# Altium schematic sheet PDU.SchDoc
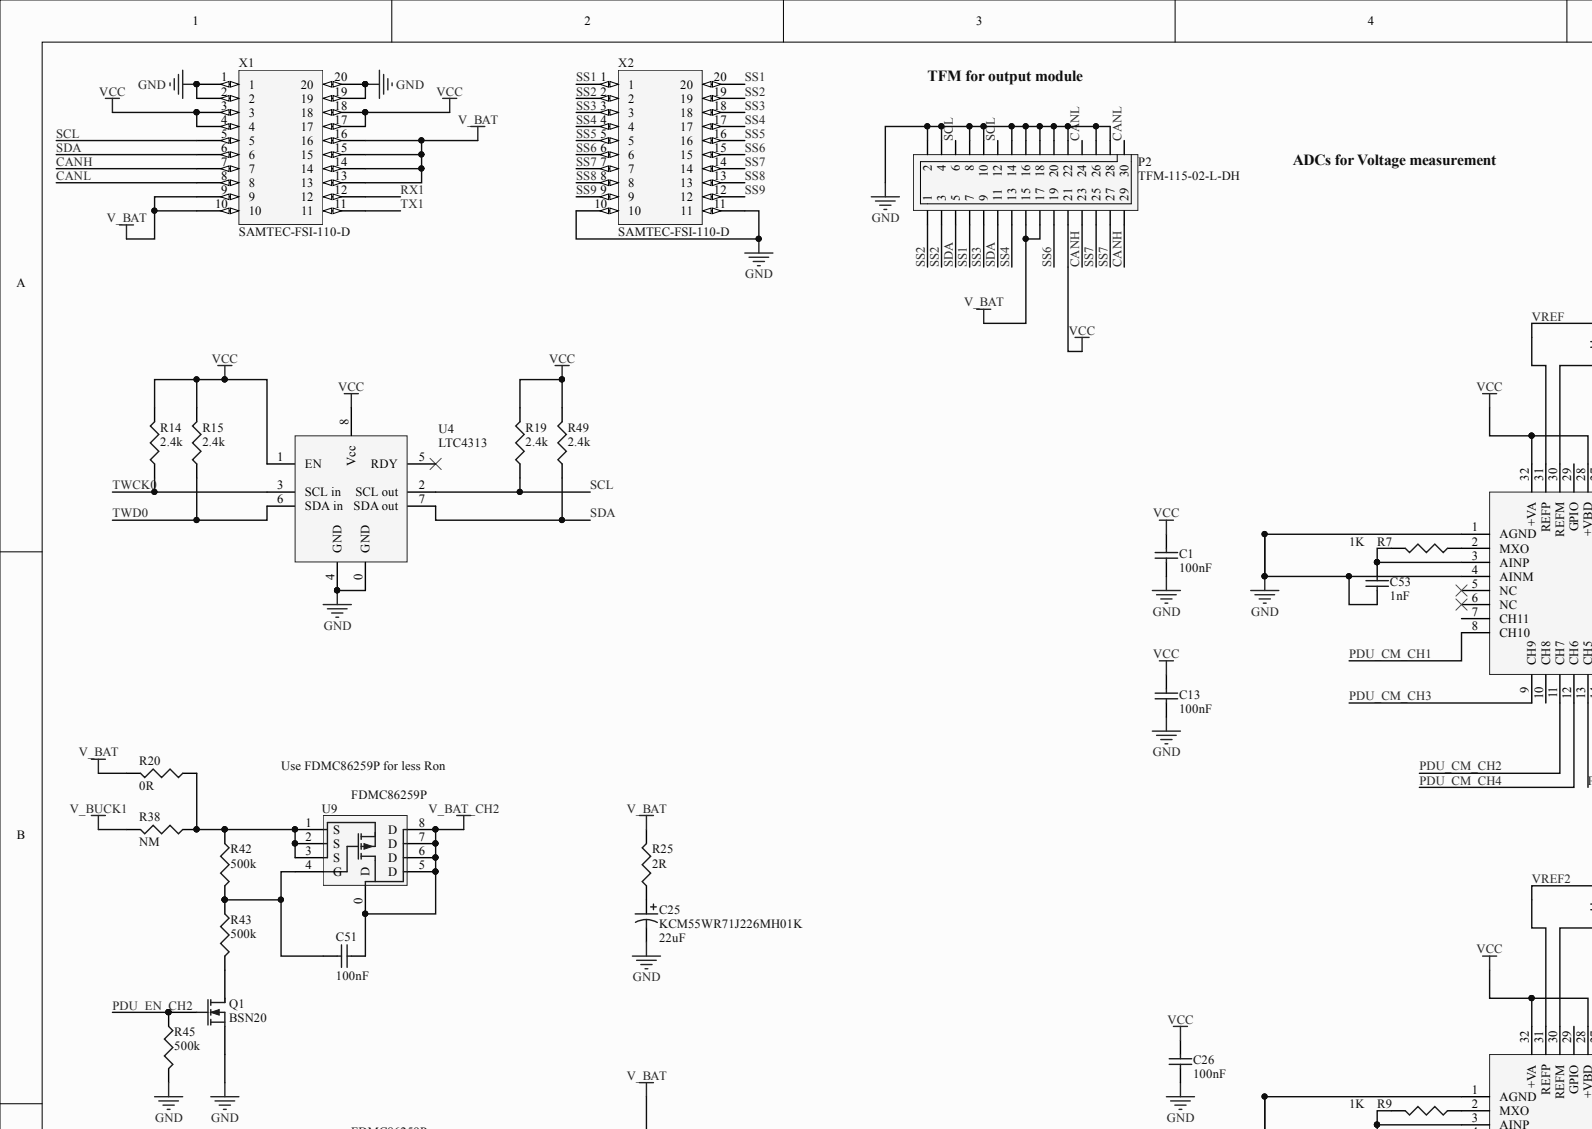
[diagram p0: PDU.SchDoc, top left]
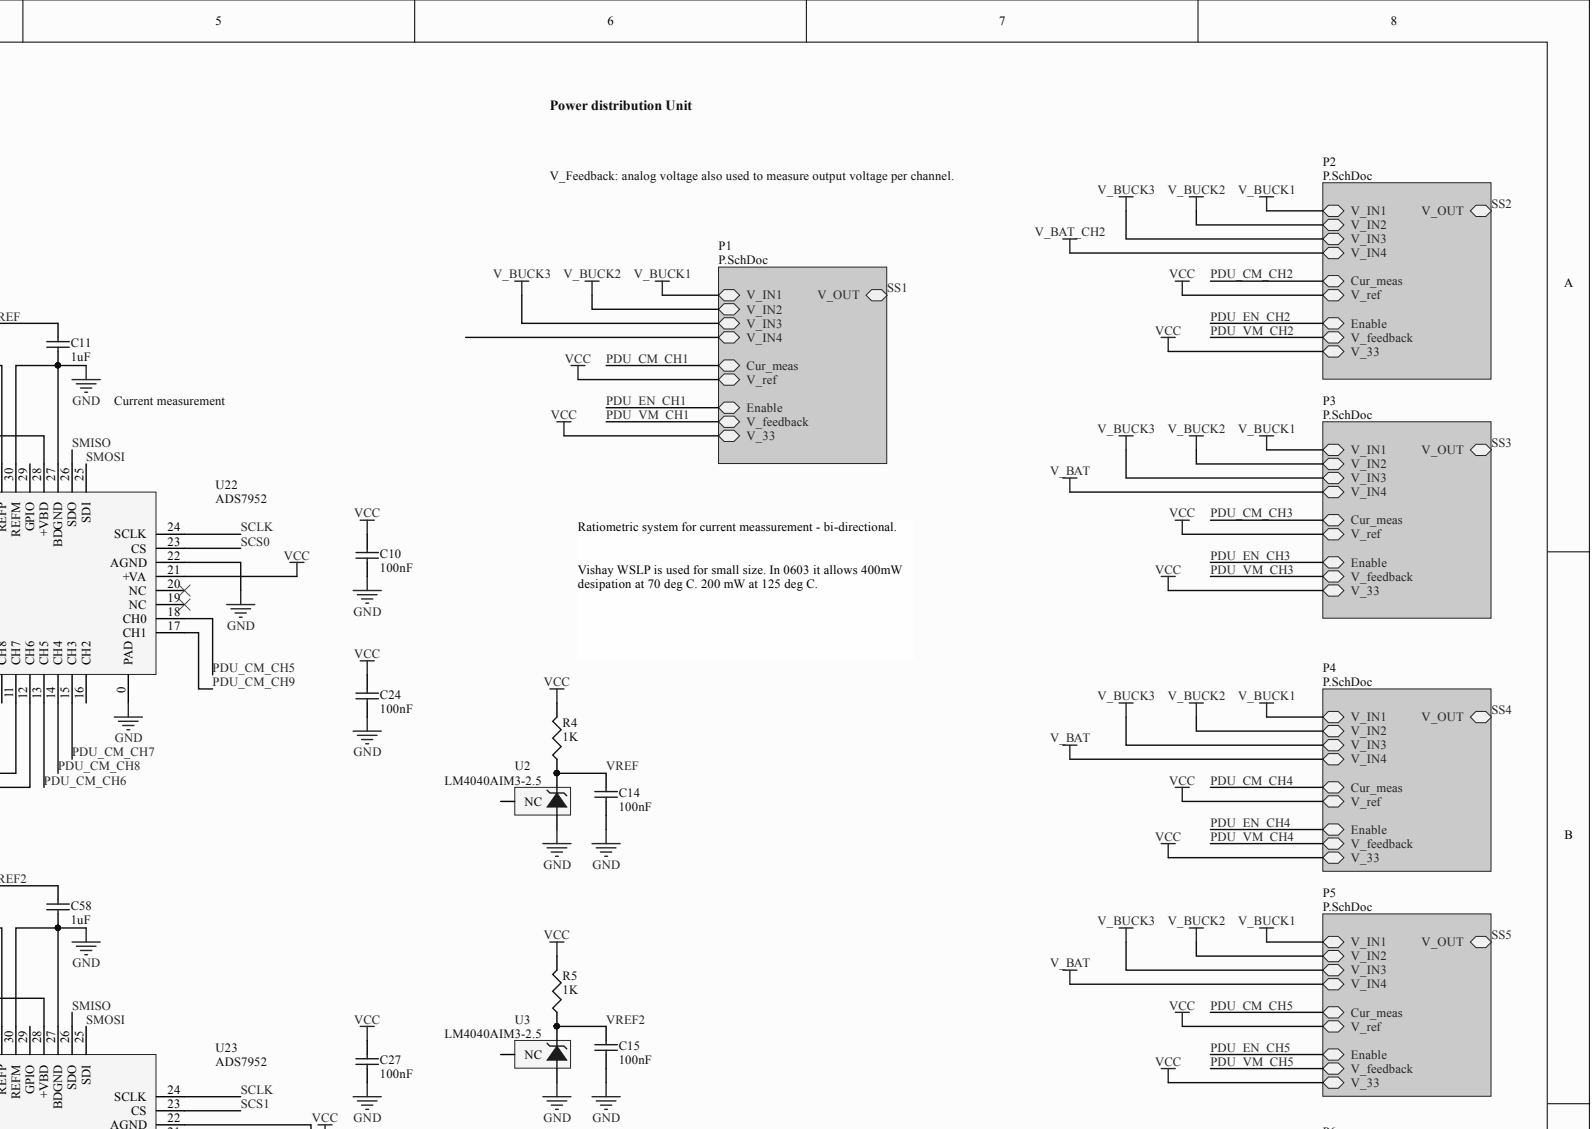
[diagram p1: PDU.SchDoc, top right]
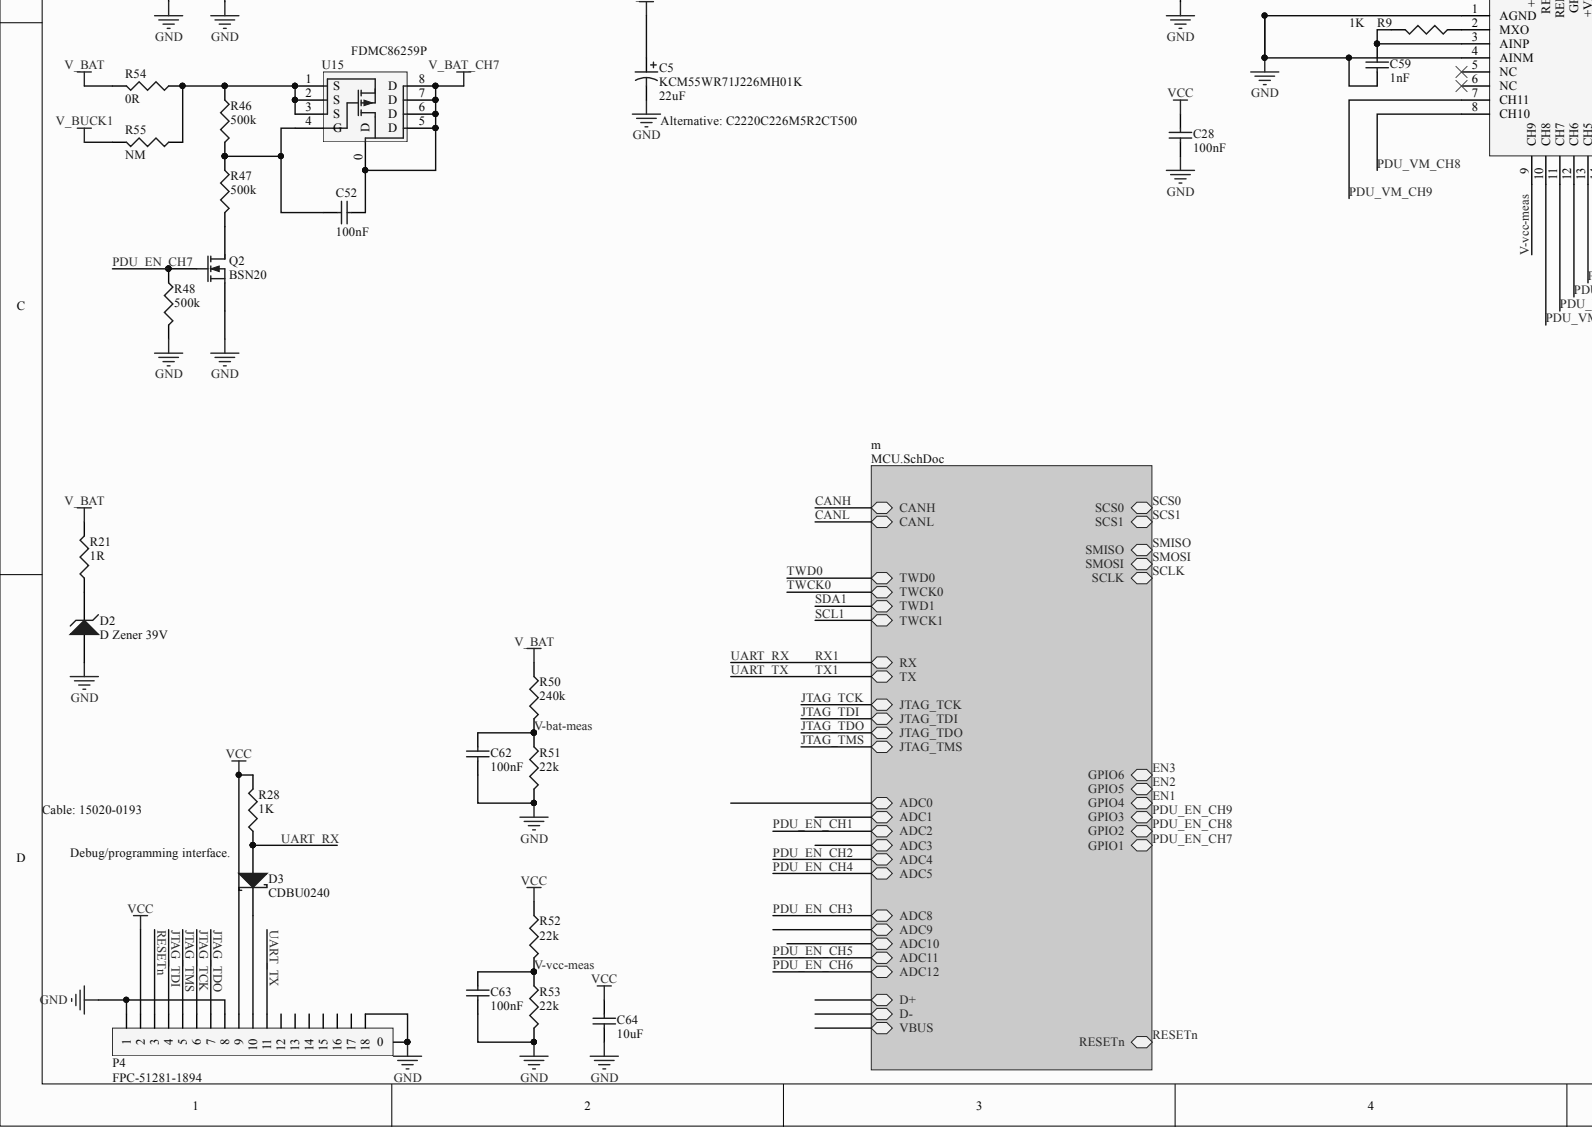
[diagram p2: PDU.SchDoc, bottom left]
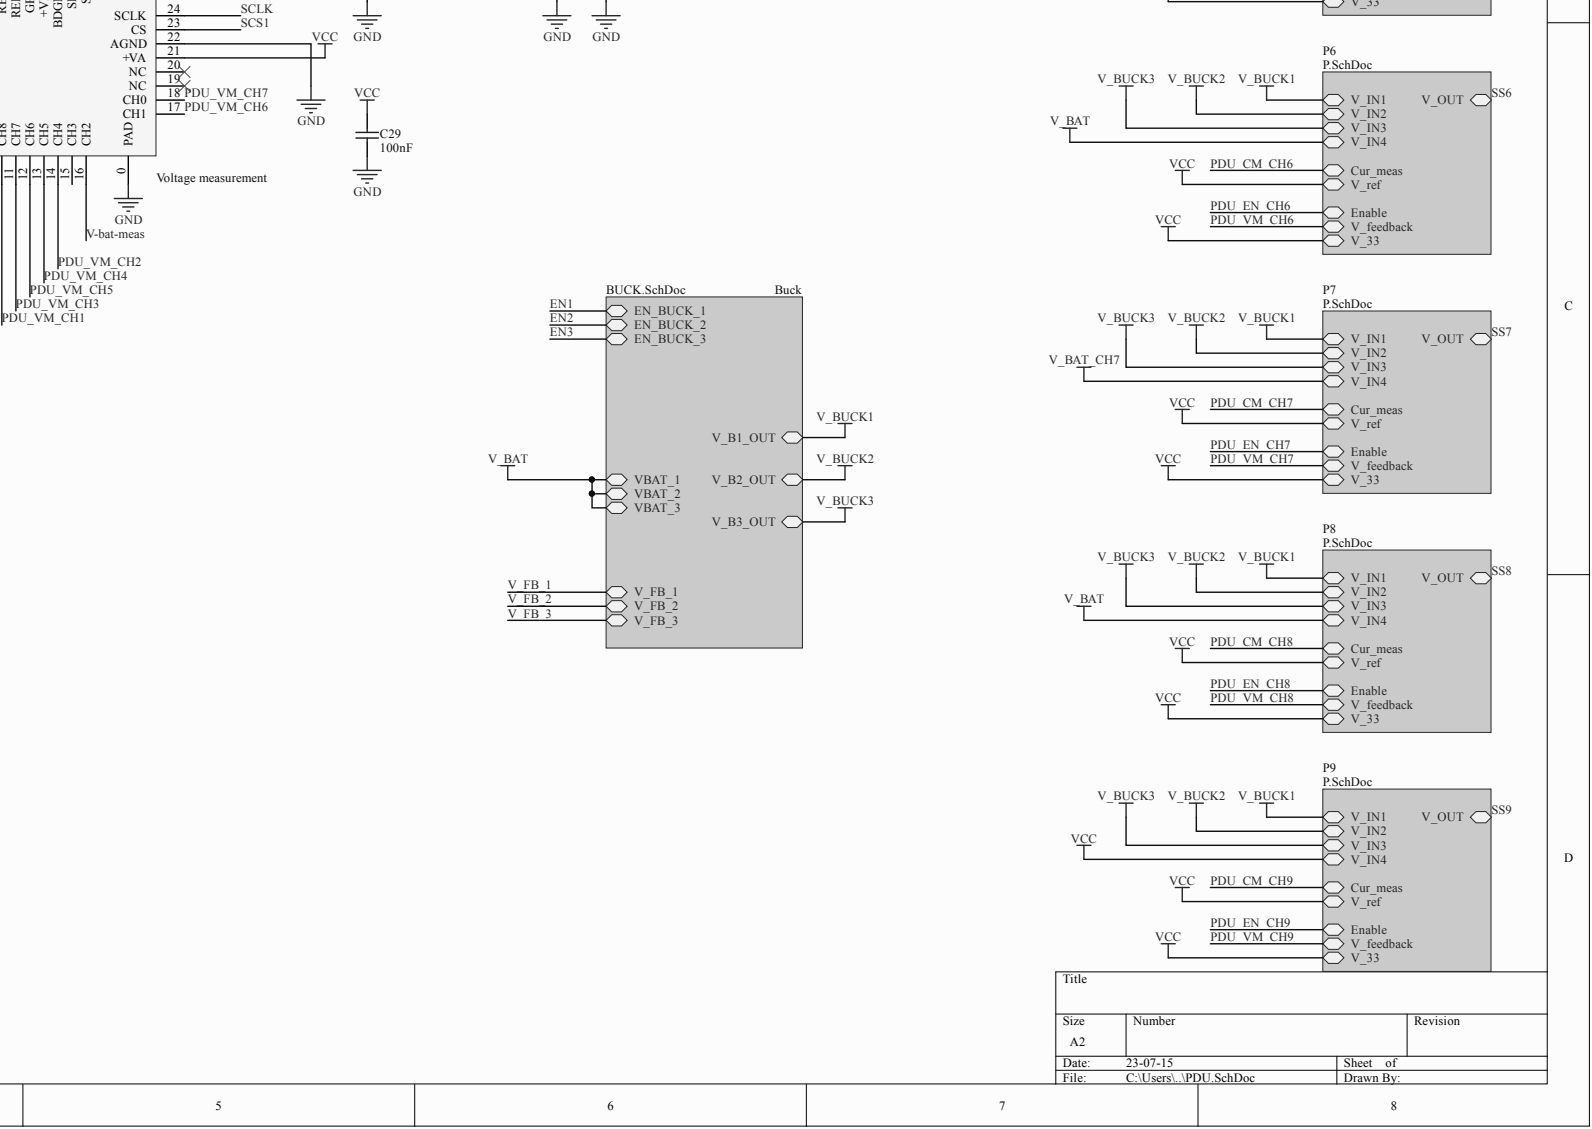
[diagram p3: PDU.SchDoc, bottom right]

## Parts
C1  value 100nF  [0402]  (hidden comment: Cap Semi)
C5  KCM55WR71J226MH01K  value 22uF  [2220-stacked-6.7]
C10  value 100nF  [0402]  (hidden comment: Cap Semi)
C11  value 1uF  [0402]  (hidden comment: Cap Semi)
C13  value 100nF  [0402]  (hidden comment: Cap Semi)
C14  value 100nF  [0402]  (hidden comment: Cap Semi)
C15  value 100nF  [0402]  (hidden comment: Cap Semi)
C24  value 100nF  [0402]  (hidden comment: Cap Semi)
C25  KCM55WR71J226MH01K  value 22uF  [2220-stacked-6.7]
C26  value 100nF  [0402]  (hidden comment: Cap Semi)
C27  value 100nF  [0402]  (hidden comment: Cap Semi)
C28  value 100nF  [0402]  (hidden comment: Cap Semi)
C29  value 100nF  [0402]  (hidden comment: Cap Semi)
C51  value 100nF  [0402]  (hidden comment: Cap Semi)
C52  value 100nF  [0402]  (hidden comment: Cap Semi)
C53  value 1nF  [0402]  (hidden comment: Cap Semi)
C58  value 1uF  [0402]  (hidden comment: Cap Semi)
C59  value 1nF  [0402]  (hidden comment: Cap Semi)
C62  value 100nF  [0402]  (hidden comment: Cap Semi)
C63  value 100nF  [0402]  (hidden comment: Cap Semi)
C64  value 10uF  [1608[0603]]  (hidden comment: Cap Semi)
D2  D Zener 39V  [0603]
D3  CDBU0240  [CD1608-0603]
P2  TFM-115-02-L-DH  [TFM-115-02-X-DH]
P4  FPC-51281-1894  [51281-1894]
Q1  BSN20  [SOT23_n]
Q2  BSN20  [SOT23_n]
R4  value 1K  [0402]  (hidden comment: Res3)
R5  value 1K  [0402]  (hidden comment: Res3)
R7  value 1K  [0402]  (hidden comment: Res3)
R9  value 1K  [0402]  (hidden comment: Res3)
R14  value 2.4k  [0402]  (hidden comment: Res3)
R15  value 2.4k  [0402]  (hidden comment: Res3)
R19  value 2.4k  [0402]  (hidden comment: Res3)
R20  value 0R  [0603R]  (hidden comment: Res3)
R21  value 1R  [J1-0603]  (hidden comment: Res3)
R25  value 2R  [14-1210]  (hidden comment: Res3)
R28  value 1K  [0402]  (hidden comment: Res3)
R38  value NM  [0603R]  (hidden comment: Res3)
R42  value 500k  [0402]  (hidden comment: Res3)
R43  value 500k  [0402]  (hidden comment: Res3)
R45  value 500k  [0402]  (hidden comment: Res3)
R46  value 500k  [0402]  (hidden comment: Res3)
R47  value 500k  [0402]  (hidden comment: Res3)
R48  value 500k  [0402]  (hidden comment: Res3)
R49  value 2.4k  [0402]  (hidden comment: Res3)
R50  value 240k  [0402]  (hidden comment: Res3)
R51  value 22k  [0402]  (hidden comment: Res3)
R52  value 22k  [0402]  (hidden comment: Res3)
R53  value 22k  [0402]  (hidden comment: Res3)
R54  value 0R  [0603R]  (hidden comment: Res3)
R55  value NM  [0603R]  (hidden comment: Res3)
U2  LM4040AIM3-2.5  [SOT23_N]
U3  LM4040AIM3-2.5  [SOT23_N]
U4  LTC4313  [DFN8-3x3-0.5p]
U9  FDMC86259P  [power33]
U15  FDMC86259P  [power33]
U22  ADS7952  [QFN32-5x5mm]
U23  ADS7952  [QFN32-5x5mm]
X1  SAMTEC-FSI-110-D  [SAMTEC-FSI-110-D]
X2  SAMTEC-FSI-110-D  [SAMTEC-FSI-110-D]

## Nets
GND: C1.1 C5.2 C10.1 C11.2 C13.1 C14.1 C15.1 C24.1 C25.2 C26.1 C27.1 C28.1 C29.1 C53.1 C58.2 C59.1 C62.1 C63.1 C64.1 D2.1 P2.2 P2.4 P2.8 P2.10 P2.14 P2.16 P2.18 P2.20 P2.22 P2.26 P2.28 P4.0 P4.1 P4.8 P4.18 Q1.3 Q2.3 R45.2 R48.2 R51.2 R53.2 U2.2 U3.2 U4.0(GND) U4.4(GND) U22.0(PAD) U22.1(AGND) U22.4(AINM) U22.22(AGND) U22.27(BDGND) U22.30(REFM) U23.0(PAD) U23.1(AGND) U23.4(AINM) U23.22(AGND) U23.27(BDGND) U23.30(REFM) X1.1 X1.2 X1.19 X1.20 X2.10 X2.11
V_BAT: C5.1 P2.15 P2.17 R20.2 R21.2 R25.2 R50.1 R54.2 X1.9 X1.10 X1.13 X1.14 X1.15 X1.16
V_BAT_CH2: C51.1 U9.0(D) U9.5(D) U9.6(D) U9.7(D) U9.8(D)
V_BAT_CH7: C52.1 U15.0(D) U15.5(D) U15.6(D) U15.7(D) U15.8(D)
V_BUCK1: R38.2 R55.2
VCC: C1.2 C10.2 C13.2 C24.2 C26.2 C27.2 C28.2 C29.2 C64.2 P2.21 P4.2 P4.9 R4.2 R5.2 R14.2 R15.2 R19.2 R28.2 R49.2 R52.1 U4.1(EN) U4.8(Vcc) U22.21(+VA) U22.28(+VBD) U22.32(+VA) U23.21(+VA) U23.28(+VBD) U23.32(+VA) X1.3 X1.4 X1.17 X1.18
CANH: P2.23 P2.29 X1.7
CANL: P2.24 P2.30 X1.8
JTAG_TCK: P4.6
JTAG_TDI: P4.4
JTAG_TDO: P4.7
JTAG_TMS: P4.5
PDU_CM_CH1: U22.8(CH10)
PDU_CM_CH2: U22.11(CH7)
PDU_CM_CH3: U22.9(CH9)
PDU_CM_CH4: U22.12(CH6)
PDU_CM_CH5: U22.18(CH0)
PDU_CM_CH6: U22.13(CH5)
PDU_CM_CH7: U22.15(CH3)
PDU_CM_CH8: U22.14(CH4)
PDU_CM_CH9: U22.17(CH1)
PDU_EN_CH2: Q1.2 R45.1
PDU_EN_CH7: Q2.2 R48.1
PDU_VM_CH1: U23.10(CH8)
PDU_VM_CH2: U23.14(CH4)
PDU_VM_CH3: U23.11(CH7)
PDU_VM_CH4: U23.13(CH5)
PDU_VM_CH5: U23.12(CH6)
PDU_VM_CH6: U23.17(CH1)
PDU_VM_CH7: U23.18(CH0)
PDU_VM_CH8: U23.8(CH10)
PDU_VM_CH9: U23.7(CH11)
RESETN: P4.3
RX1: D3.1 R28.1 X1.12
SCL: P2.6 P2.12 R19.1 U4.2(SCL_out) X1.5
SCLK: U22.24(SCLK) U23.24(SCLK)
SCS0: U22.23(CS)
SCS1: U23.23(CS)
SDA: P2.5 P2.11 R49.1 U4.7(SDA_out) X1.6
SMISO: U22.26(SDO) U23.26(SDO)
SMOSI: U22.25(SDI) U23.25(SDI)
SS1: P2.7 X2.1 X2.20
SS2: P2.1 P2.3 X2.2 X2.19
SS3: P2.9 X2.3 X2.18
SS4: P2.13 X2.4 X2.17
SS5: X2.5 X2.16
SS6: P2.19 X2.6 X2.15
SS7: P2.25 P2.27 X2.7 X2.14
SS8: X2.8 X2.13
SS9: X2.9 X2.12
TWCK0: R14.1 U4.3(SCL_in)
TWD0: R15.1 U4.6(SDA_in)
TX1: P4.11 X1.11
V-BAT-MEAS: C62.2 R50.2 R51.1 U23.16(CH2)
V-VCC-MEAS: C63.2 R52.2 R53.1 U23.9(CH9)
VREF: C11.1 C14.2 R4.1 U2.1 U22.31(REFP)
VREF2: C15.2 C58.1 R5.1 U3.1 U23.31(REFP)
NetC25_1: C25.1 R25.1
NetC51_2: C51.2 R42.2 R43.1 U9.4(G)
NetC52_2: C52.2 R46.2 R47.1 U15.4(G)
NetC53_2: C53.2 R7.2 U22.3(AINP)
NetC59_2: C59.2 R9.2 U23.3(AINP)
NetD2_2: D2.2 R21.1
NetD3_2: D3.2 P4.10
NetP4_12: P4.12
NetP4_13: P4.13
NetP4_14: P4.14
NetP4_15: P4.15
NetP4_16: P4.16
NetP4_17: P4.17
NetQ1_1: Q1.1 R43.2
NetQ2_1: Q2.1 R47.2
NetR7_1: R7.1 U22.2(MXO)
NetR9_1: R9.1 U23.2(MXO)
NetR20_1: R20.1 R38.1 R42.1 U9.1(S) U9.2(S) U9.3(S)
NetR46_1: R46.1 R54.1 R55.1 U15.1(S) U15.2(S) U15.3(S)
NetU2_3: U2.3
NetU3_3: U3.3
NetU4_5: U4.5(RDY)
NetU22_5: U22.5(NC)
NetU22_6: U22.6(NC)
NetU22_7: U22.7(CH11)
NetU22_10: U22.10(CH8)
NetU22_16: U22.16(CH2)
NetU22_19: U22.19(NC)
NetU22_20: U22.20(NC)
NetU22_29: U22.29(GPIO)
NetU23_5: U23.5(NC)
NetU23_6: U23.6(NC)
NetU23_15: U23.15(CH3)
NetU23_19: U23.19(NC)
NetU23_20: U23.20(NC)
NetU23_29: U23.29(GPIO)
ADC0:
ADC1:
ADC3:
ADC9:
ADC10:
D+:
D-:
EN1:
EN2:
EN3:
PDU_EN_CH1:
PDU_EN_CH3:
PDU_EN_CH4:
PDU_EN_CH5:
PDU_EN_CH6:
PDU_EN_CH8:
PDU_EN_CH9:
SCL1:
SDA1:
V_BUCK2:
V_BUCK3:
V_FB_1:
V_FB_2:
V_FB_3:
V_IN4:
VBUS:

## Library data of the parts
C1 C10 C11 C13-C15 C24 C26-C29 C51-C53 C58 C59 C62-C64 = Cap Semi: Capacitor (Semiconductor SIM Model); Component Kind: Standard; LatestRevisionDate: 29-May-2009; LatestRevisionNote: IPC-7351 Footprint Added.; Library Name: Miscellaneous Devices.IntLib; PackageDescription: Chip Capacitor; PackageReference: C1206; Published: 8-Jun-2000; Publisher: Altium Limited; Signal Integrity: Cap Semi; Simulation: CAP
C5 C25 = Cap Pol3: Polarized Capacitor (Surface Mount); Component Kind: Standard; LatestRevisionDate: 29-May-2009; LatestRevisionNote: IPC-7351 Footprint Added.; Library Name: Miscellaneous Devices.IntLib; PackageDescription: Chip Capacitor; PackageReference: C0805; Published: 8-Jun-2000; Publisher: Altium Limited; Signal Integrity: Cap Pol; Simulation: CAP
D2 = D Zener: Zener Diode; Component Kind: Standard; LatestRevisionDate: 17-Jul-2002; LatestRevisionNote: Re-released for DXP Platform.; Library Name: Miscellaneous Devices.IntLib; PackageDescription: Diode, Thru-Hole; 2 Leads; 0.7 in Pin Spacing; PackageReference: DIODE-0.7; Published: 8-Jun-2000; Publisher: Altium Limited; Signal Integrity: D Zener; Simulation: ZENER
D3 = D Schottky: Schottky Diode; Code_JEDEC: DO-214-AA; Component Kind: Standard; LatestRevisionDate: 17-Jul-2002; LatestRevisionNote: Re-released for DXP Platform.; Library Name: Miscellaneous Devices.IntLib; PackageReference: SMB; Published: 8-Jun-2000; Publisher: Altium Limited; Signal Integrity: D Schottky; Simulation: SKYDIODE
P2 = TFM-115-02-L-DH: 15 pos 2 row 30 pin TFM, samtec; Component Kind: Standard; Library Name: sessentas.SchLib; PartNumber: TFM-115-02-L-DH
P4 = FPC-51281-1894: FPC, 0.5mm pitch, Molex, 1.2mm height.; Component Kind: Standard; Library Name: sessentas.SchLib; PartNumber: 51281-1894
Q1 Q2 = MOSFET-N: N-Channel MOSFET; Component Kind: Standard; LatestRevisionDate: 17-Jul-2002; LatestRevisionNote: Re-released for DXP Platform.; Library Name: Miscellaneous Devices.IntLib; PackageReference: E3; PackageVersion: 1995/1996; Published: 8-Jun-2000; Publisher: Altium Limited; Simulation: NMOS
R4 R5 R7 R9 R14 R15 R19-R21 R25 R28 R38 R42 R43 R45-R55 = Res3: Resistor; Component Kind: Standard; LatestRevisionDate: 29-May-2009; LatestRevisionNote: IPC-7351 Footprint Added.; Library Name: Miscellaneous Devices.SchLib; PackageDescription: Chip Resistor; PackageReference: J1-0603; Published: 8-Jun-2000; Publisher: Altium Limited; Signal Integrity: Res; Simulation: RESISTOR
U2 U3 = LM4040AIM3-2.5: Precision Micropower Shunt Voltage Reference; Manufacturer: National Semiconductor; CompType: Voltage Reference IC; PackageTopMarking: R2A*XTT; PowerWise: N; Component Kind: Standard; ComponentLink1Description: LM4040 Datasheet; ComponentLink1URL: http://www.national.com/ds/LM/LM4040.pdf; ComponentLink2Description: LM4040 Web Page; ComponentLink2URL: http://www.national.com/pf/LM/LM4040.html; ComponentLink3Description: MF03A Package Page; ComponentLink3URL: http://www.national.com/packaging/folders/mf03a.html; DatasheetVersion: Apr-2005; DigiKeyPartNumber: LM4040AIM3-2.5CT-ND; Library Name: NSC Voltage Reference.IntLib; PackageDescription: Molded SOT-23, .115 x .051 x .037in Body, 3 Lead, .075 in Pitch; PackageReference: MF03A; PackageVersion: revB, Mar-2003; PartNumber: LM4040AIM3-2.5; PinCount: 3; Published: 4-Dec-2008; Publisher: Altium Limited; RoHS: O
U4 = LTC4313: Manufacturer: Linear Technology; PartNumber: LTC4313IDD-3#PBF; Component Kind: Standard; Library Name: sessentas.SchLib
U9 U15 = FDMC86261P: P-Channel PowerTrench MOSFET -150 V, -3A, 130mOhm.; Component Kind: Standard; Library Name: sessentas.SchLib; PartNunber: FDMC86259P
U22 U23 = ADS7952: 12-bit, 12-ch SAR ADC, 1MSPS, Single-ended, SPI.; Component Kind: Standard; Library Name: sessentas.SchLib; PartNumber: ADS7952
X1 X2 = SAMTEC-FSI-110-D: Component Kind: Standard; Library Name: Johan.SCHLIB
